# Altium schematic sheet C50-00020_Main.SchDoc(C50-00020_Main)
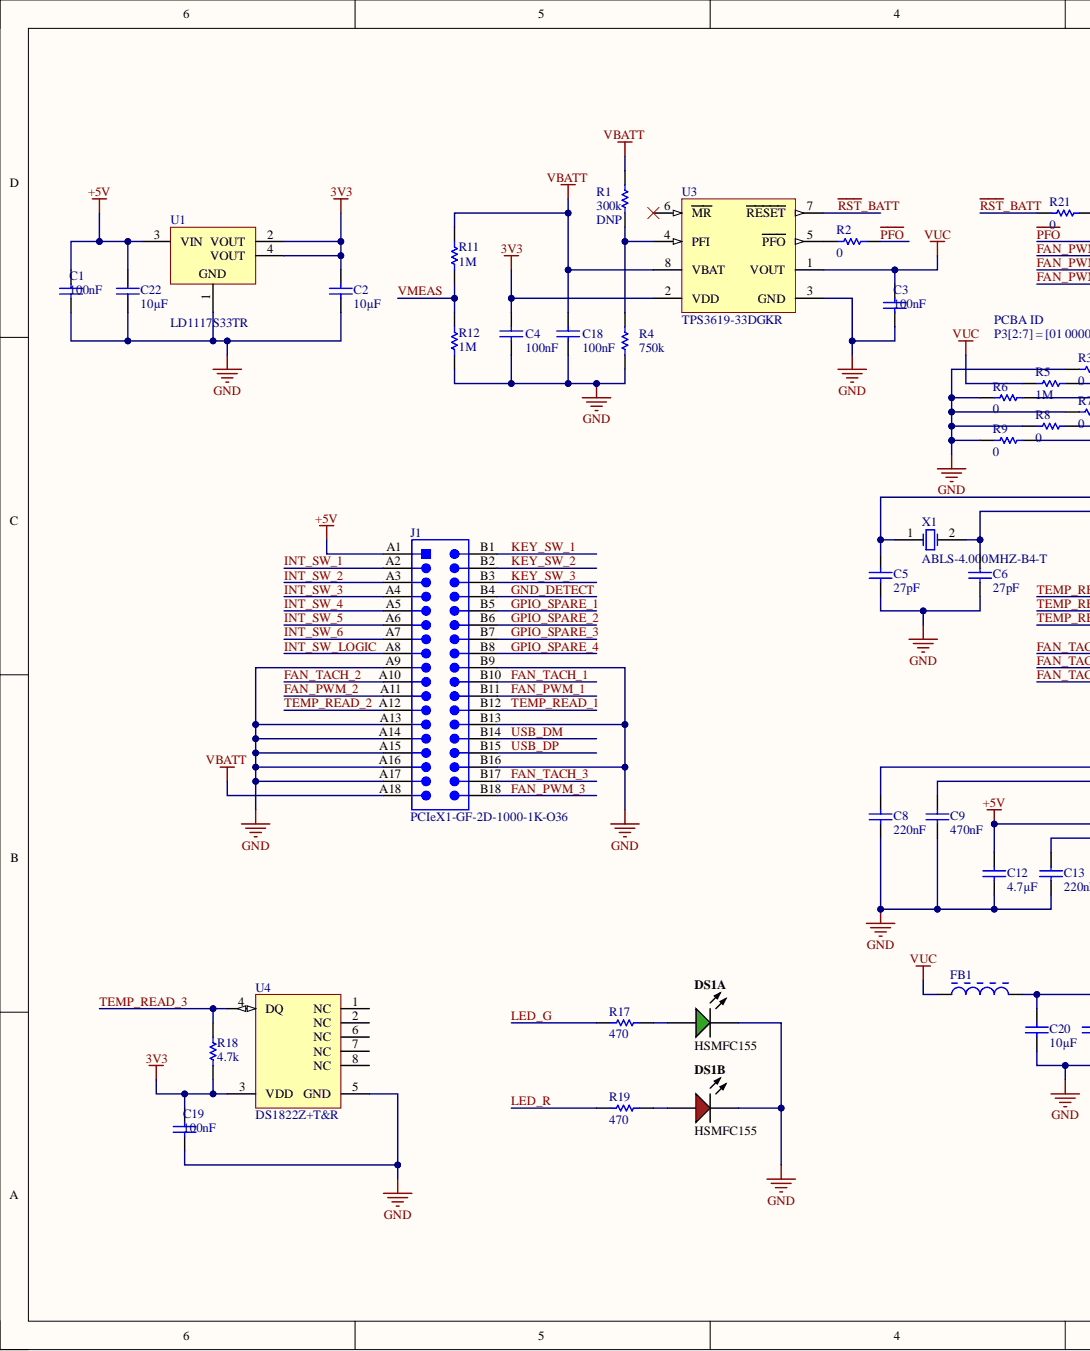
[diagram p0: C50-00020_Main.SchDoc, left]
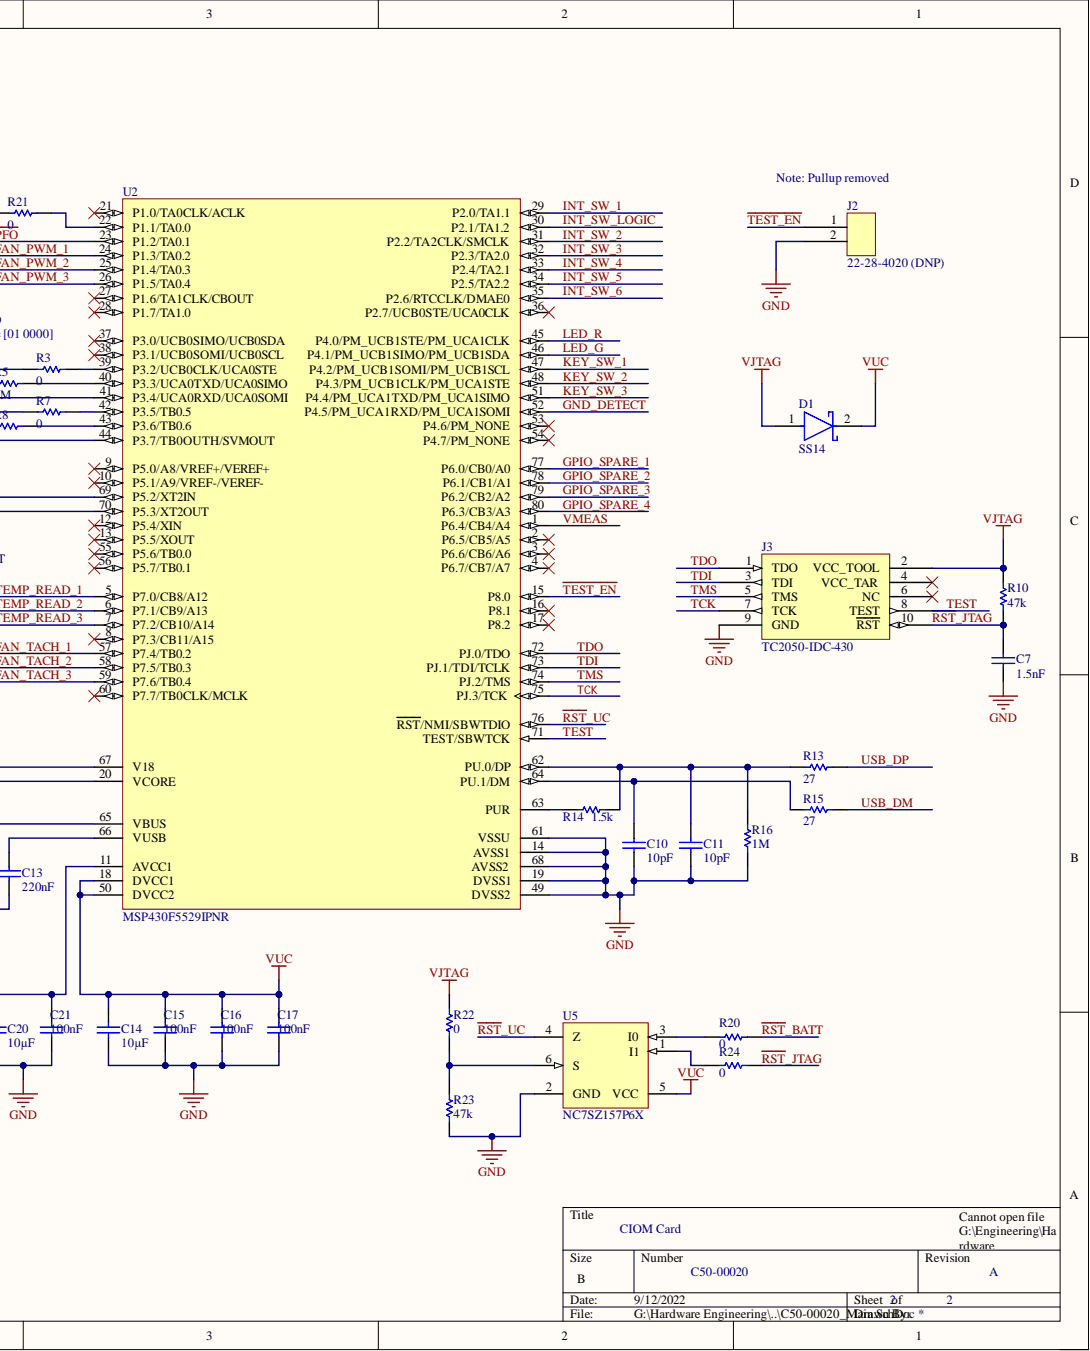
[diagram p1: C50-00020_Main.SchDoc, right]

## Parts
C1  value 100nF  [CAPC0402(1005)60_N]  (hidden comment: CL05A104KA5NNNC)
C2  value 10µF  [CAPC1608X100X20VL10T10]  (hidden comment: C1608X5R1E106M080AC)
C3  value 100nF  [CAPC0402(1005)60_N]  (hidden comment: CL05A104KA5NNNC)
C4  value 100nF  [CAPC0402(1005)60_N]  (hidden comment: CL05A104KA5NNNC)
C5  value 27pF  Capacitance: 27pF  [CAPC1005X56X25LL10T15]  (hidden comment: 04025A270JAT2A)
C6  value 27pF  Capacitance: 27pF  [CAPC1005X56X25LL10T15]  (hidden comment: 04025A270JAT2A)
C7  value 1.5nF  Capacitance: 1.5nF  [CAPC1005X56X25ML10T15]  (hidden comment: 04025C152KAT2A)
C8  value 220nF  Capacitance: 220nF  [CAPC1608X94X35ML15T15]  (hidden comment: 06033C224KAT2A)
C9  value 470nF  Capacitance: 470nF  [CAPC1608X90X35ML15T15]  (hidden comment: C0603C474K4PACTU)
C10  value 10pF  Capacitance: 10pF  [CAPC1005X55X25NL05T05]  (hidden comment: CC0402JRNPO9BN100)
C11  value 10pF  Capacitance: 10pF  [CAPC1005X55X25NL05T05]  (hidden comment: CC0402JRNPO9BN100)
C12  value 4.7µF  [CAPC1608X90X35NL10T25]  (hidden comment: EMK107ABJ475KA-T)
C13  value 220nF  Capacitance: 220nF  [CAPC1608X94X35ML15T15]  (hidden comment: 06033C224KAT2A)
C14  value 10µF  [CAPC1608X100X20VL10T10]  (hidden comment: C1608X5R1E106M080AC)
C15  value 100nF  [CAPC0402(1005)60_N]  (hidden comment: CL05A104KA5NNNC)
C16  value 100nF  [CAPC0402(1005)60_N]  (hidden comment: CL05A104KA5NNNC)
C17  value 100nF  [CAPC0402(1005)60_N]  (hidden comment: CL05A104KA5NNNC)
C18  value 100nF  [CAPC0402(1005)60_N]  (hidden comment: CL05A104KA5NNNC)
C19  value 100nF  [CAPC0402(1005)60_N]  (hidden comment: CL05A104KA5NNNC)
C20  value 10µF  [CAPC1608X100X20VL10T10]  (hidden comment: C1608X5R1E106M080AC)
C21  value 100nF  [CAPC0402(1005)60_N]  (hidden comment: CL05A104KA5NNNC)
C22  value 10µF  [CAPC1608X100X20VL10T10]  (hidden comment: C1608X5R1E106M080AC)
D1  SS14  [FP-403AE-MFG]
DS1  HSMFC155  [AVAG-HSMF-C15X_V]  (parts A,B)
FB1  BLM15AG102SN1D  [MURA-BLM15-CHIP-2_V]  (value not drawn)
J1  PCIeX1-GF-2D-1000-1K-O36  [PCIeX1-GF-2D-1000-1K-O36]  (no BOM)
J2  22-28-4020 (DNP)  [22-28-4020-Footprint-1]  (no BOM)
J3  TC2050-IDC-430  [TC2050-IDC-430-Footprint-1]  (no BOM)
R1  DNP  value 300k  [RESC1609X50X30NL10T20]  (no BOM)
R2  value 0  [RESC1608X55X25LL10T15]  (hidden comment: RC0603FR-070RL)
R3  value 0  [RESC1608X55X25LL10T15]  (hidden comment: RC0603FR-070RL)
R4  value 750k  [RESC1609X50X30NL10T20]  (hidden comment: CRCW0603750KFKEA)
R5  value 1M  [RESC1608X55X25ML10T15]  (hidden comment: RC0603FR-071ML)
R6  value 0  [RESC1608X55X25LL10T15]  (hidden comment: RC0603FR-070RL)
R7  RC0603FR-070RL  [RESC1608X55X25LL10T15]  (value not drawn)
R8  value 0  [RESC1608X55X25LL10T15]  (hidden comment: RC0603FR-070RL)
R9  value 0  [RESC1608X55X25LL10T15]  (hidden comment: RC0603FR-070RL)
R10  value 47k  [RESC1005X40X25LL10T10]  (hidden comment: CRG0402F47K)
R11  value 1M  [RESC1608X55X25LL10T15]  (hidden comment: RC0603FR-071ML)
R12  value 1M  [RESC1608X55X25LL10T15]  (hidden comment: RC0603FR-071ML)
R13  value 27  [RESC1005X40X25NL05T05]  (hidden comment: CRCW040227R0FKED)
R14  value 1.5k  [RESC1005X40X25ML05T10]  (hidden comment: RC0402FR-071K5L)
R15  value 27  [RESC1005X40X25NL05T05]  (hidden comment: CRCW040227R0FKED)
R16  value 1M  [RESC1608X55X25LL10T15]  (hidden comment: RC0603FR-071ML)
R17  value 470  [RESC1608X55X25NL10T15]  (hidden comment: AC0603FR-07470RL)
R18  value 4.7k  [RESC1608X55X25ML10T15]  (hidden comment: RC0603FR-074K7L)
R19  value 470  [RESC1608X55X25NL10T15]  (hidden comment: AC0603FR-07470RL)
R20  value 0  [RESC1608X55X25LL10T15]  (hidden comment: RC0603FR-070RL)
R21  value 0  [RESC1608X55X25LL10T15]  (hidden comment: RC0603FR-070RL)
R22  value 0  [RESC1608X55X25LL10T15]  (hidden comment: RC0603FR-070RL)
R23  value 47k  [RESC1005X40X25LL10T10]  (hidden comment: CRG0402F47K)
R24  value 0  [RESC1608X55X25LL10T15]  (hidden comment: RC0603FR-070RL)
U1  LD1117S33TR  [SOT-223_L]
U2  MSP430F5529IPNR  [PN0080A_L]
U3  TPS3619-33DGKR  [DGK0008A_M]
U4  DS1822Z+T&R  [MAXM-S8+2_N]
U5  NC7SZ157P6X  [FAIR-SC70-6_V]
X1  ABLS-4.000MHZ-B4-T  [ABLS-4.000MHZ-B4-T-Footprint-1]

## Nets
3V3: C2.2 C4.2 C19.2 R18.1 U1.2(VOUT) U1.4(VOUT) U3.2(VDD) U4.3(VDD)
+5V: C1.2 C12.1 C22.2 J1.A1 U1.3(VIN) U2.65(VBUS)
GND: C1.1 C2.1 C3.1 C4.1 C5.1 C6.1 C7.1 C8.2 C9.2 C10.1 C11.1 C12.2 C13.1 C14.1 C15.1 C16.1 C17.1 C18.1 C19.1 C20.1 C21.1 C22.1 DS1.2 DS1.4 J1.A9 J1.A13 J1.A14 J1.A15 J1.A16 J1.A17 J1.B9 J1.B13 J1.B16 J2.2 J3.9(GND) R3.1 R4.1 R6.1 R7.1 R8.1 R9.1 R12.1 R16.1 R23.1 U1.1 U2.14(AVSS1) U2.19(DVSS1) U2.49(DVSS2) U2.61(VSSU) U2.68(AVSS2) U3.3(GND) U4.5(GND) U5.2(GND)
VBATT: C18.2 J1.A18 R1.2 R11.2 U3.8(VBAT)
VJTAG: D1.1 J3.2(VCC_TOOL) R10.2 R22.1
VUC: C3.2 C14.2 C15.2 C16.2 C17.2 D1.2 FB1.1 R5.2 U2.18(DVCC1) U2.50(DVCC2) U3.1(VOUT) U5.5(VCC)
FAN_PWM_1: J1.B11 U2.24(P1.3/TA0.2)
FAN_PWM_2: J1.A11 U2.25(P1.4/TA0.3)
FAN_PWM_3: J1.B18 U2.26(P1.5/TA0.4)
FAN_TACH_1: J1.B10 U2.57(P7.4/TB0.2)
FAN_TACH_2: J1.A10 U2.58(P7.5/TB0.3)
FAN_TACH_3: J1.B17 U2.59(P7.6/TB0.4)
GND_DETECT: J1.B4 U2.52(P4.5/PM_UCA1RXD/PM_UCA1SOMI)
GPIO_SPARE_1: J1.B5 U2.77(P6.0/CB0/A0)
GPIO_SPARE_2: J1.B6 U2.78(P6.1/CB1/A1)
GPIO_SPARE_3: J1.B7 U2.79(P6.2/CB2/A2)
GPIO_SPARE_4: J1.B8 U2.80(P6.3/CB3/A3)
INT_SW_1: J1.A2 U2.29(P2.0/TA1.1)
INT_SW_2: J1.A3 U2.31(P2.2/TA2CLK/SMCLK)
INT_SW_3: J1.A4 U2.32(P2.3/TA2.0)
INT_SW_4: J1.A5 U2.33(P2.4/TA2.1)
INT_SW_5: J1.A6 U2.34(P2.5/TA2.2)
INT_SW_6: J1.A7 U2.35(P2.6/RTCCLK/DMAE0)
INT_SW_LOGIC: J1.A8 U2.30(P2.1/TA1.2)
KEY_SW_1: J1.B1 U2.47(P4.2/PM_UCB1SOMI/PM_UCB1SCL)
KEY_SW_2: J1.B2 U2.48(P4.3/PM_UCB1CLK/PM_UCA1STE)
KEY_SW_3: J1.B3 U2.51(P4.4/PM_UCA1TXD/PM_UCA1SIMO)
LED_G: R17.1 U2.46(P4.1/PM_UCB1SIMO/PM_UCB1SDA)
LED_R: R19.1 U2.45(P4.0/PM_UCB1STE/PM_UCA1CLK)
~{PFO}: R2.1 U2.23(P1.2/TA0.1)
~{RST}_BATT: R20.1 R21.2 U3.7(RESET)
~{RST}_JTAG: C7.2 J3.10(RST) R10.1 R24.1
~{RST}_UC: U2.76(RST/NMI/SBWTDIO) U5.4(Z)
~{TEST_EN}: J2.1 U2.15(P8.0)
TCK: J3.7(TCK) U2.75(PJ.3/TCK)
TDI: J3.3(TDI) U2.73(PJ.1/TDI/TCLK)
TDO: J3.1(TDO) U2.72(PJ.0/TDO)
TEMP_READ_1: J1.B12 U2.5(P7.0/CB8/A12)
TEMP_READ_2: J1.A12 U2.6(P7.1/CB9/A13)
TEMP_READ_3: R18.2 U2.7(P7.2/CB10/A14) U4.4(DQ)
TEST: J3.8(TEST) U2.71(TEST/SBWTCK)
TMS: J3.5(TMS) U2.74(PJ.2/TMS)
USB_DM: J1.B14 R15.2
USB_DP: J1.B15 R13.2
VMEAS: R11.1 R12.2 U2.1(P6.4/CB4/A4)
NetC5_2: C5.2 U2.69(P5.2/XT2IN) X1.1
NetC6_2: C6.2 U2.70(P5.3/XT2OUT) X1.2
NetC8_1: C8.1 U2.67(V18)
NetC9_1: C9.1 U2.20(VCORE)
NetC10_2: C10.2 R15.1 U2.64(PU.1/DM)
NetC11_2: C11.2 R13.1 R14.2 R16.2 U2.62(PU.0/DP)
NetC13_2: C13.2 U2.66(VUSB)
NetC20_2: C20.2 C21.2 FB1.2 U2.11(AVCC1)
NetDS1_1: DS1.1 R17.2
NetDS1_3: DS1.3 R19.2
NetR1_1: R1.1 R4.2 U3.4(PFI)
NetR2_2: R2.2 U3.5(PFO)
NetR3_2: R3.2 U2.39(P3.2/UCB0CLK/UCA0STE)
NetR5_1: R5.1 U2.40(P3.3/UCA0TXD/UCA0SIMO)
NetR6_2: R6.2 U2.41(P3.4/UCA0RXD/UCA0SOMI)
NetR7_2: R7.2 U2.42(P3.5/TB0.5)
NetR8_2: R8.2 U2.43(P3.6/TB0.6)
NetR9_2: R9.2 U2.44(P3.7/TB0OUTH/SVMOUT)
NetR14_1: R14.1 U2.63(PUR)
NetR20_2: R20.2 U5.3(I0)
NetR21_1: R21.1 U2.22(P1.1/TA0.0)
NetR22_2: R22.2 R23.2 U5.6(S)
NetR24_2: R24.2 U5.1(I1)

Not connected: J3 4(VCC_TAR) 6(NC); U2 2(P6.5/CB5/A5) 3(P6.6/CB6/A6) 4(P6.7/CB7/A7) 8(P7.3/CB11/A15) 9(P5.0/A8/VREF+/VEREF+) 10(P5.1/A9/VREF-/VEREF-) 12(P5.4/XIN) 13(P5.5/XOUT) 16(P8.1) 17(P8.2) 21(P1.0/TA0CLK/ACLK) 27(P1.6/TA1CLK/CBOUT) 28(P1.7/TA1.0) 36(P2.7/UCB0STE/UCA0CLK) 37(P3.0/UCB0SIMO/UCB0SDA) 38(P3.1/UCB0SOMI/UCB0SCL) 53(P4.6/PM_NONE) 54(P4.7/PM_NONE) 55(P5.6/TB0.0) 56(P5.7/TB0.1) 60(P7.7/TB0CLK/MCLK); U3 6(MR); U4 1(NC) 2(NC) 6(NC) 7(NC) 8(NC)

## Library data of the parts
C1 C3 C4 C15-C19 C21 = C51-C0064-5: CAP, Ceramic, 0.1uF, 0402, 25V, 10%, X5R, SMD; Tolerance: 20%; Case/Package: 0402; Component Kind: Standard; Max Operating Temperature: 125°C; Min Operating Temperature: -55°C; Voltage Rating: 25V; Library Name: AGS, LLC
C2 C14 C20 C22 = C51-C0063-5: CAP, Ceramic, 10uF, 0603, 25V, 20%, X5R, SMD; Capacitance: 10uF; Case Code (Imperial): 0603; Case Code (Metric): 1608; Case/Package: 0603; Component Kind: Standard; Composition: Ceramic; Depth: 0.8mm; Dielectric: X5R; Dielectric Material: Ceramic; Height: 0.8mm; Lead Free: Lead Free; Length: 1.6mm; Max Operating Temperature: 85°C; Min Operating Temperature: -55°C; Mount: Surface Mount; Package Quantity: 1; Packaging: Tape and Reel; REACH SVHC: No SVHC; Termination: SMD/SMT; Thickness: 0.039inch; Tolerance: 20%; Voltage Rating: 25V; Voltage Rating (DC): 25V; Weight: 7.1E-05oz; Width: 0.8mm; Library Name: AGS, LLC
C5 C6 = C51-C0028-3: CAP, Ceramic, 27pF, 0402, 50V, 5%, C0G/NP0, SMD; Dielectric: C0G; Temperature Coefficient: 0ppm/°C; Case Code (Imperial): 0402; Case Code (Metric): 1005; Case/Package: 0402; Component Kind: Standard; Contact Plating: Tin,Matte; Depth: 0.5mm; Dielectric Material: Ceramic; Height: 0.5mm; Lead Free: Lead Free; Length: 1mm; Max Operating Temperature: 125°C; Min Operating Temperature: -55°C; Mount: Surface Mount,PCB; Number of Pins: 2; Package Quantity: 1; Packaging: Tape and Reel; Radiation Hardening: No; REACH SVHC: No SVHC; Termination: SMD/SMT; Thickness: 0.022inch; Tolerance: 5%; Voltage: 50V; Voltage Rating: 50V; Voltage Rating (DC): 50V; Width: 0.02inch; Library Name: AGS, LLC
C7 = C51-C0027-4: CAP, Ceramic, 1500pF, 0402, 50V, 10%, X7R, SMD; Case Code (Imperial): 0402; Case Code (Metric): 1005; Case/Package: 0402; Component Kind: Standard; Contact Plating: Tin,Matte; Depth: 0.5mm; Dielectric: X7R; Dielectric Material: Ceramic; Height: 0.5mm; Lead Free: Lead Free; Length: 1mm; Max Operating Temperature: 125°C; Min Operating Temperature: -55°C; Mount: Surface Mount,PCB; Number of Pins: 2; Package Quantity: 1; Packaging: Tape and Reel; Radiation Hardening: No; REACH SVHC: No SVHC; Termination: SMD/SMT; Thickness: 0.022inch; Tolerance: 10%; Voltage: 50V; Voltage Rating: 50V; Voltage Rating (DC): 50V; Width: 0.02inch; Library Name: AGS, LLC
C8 C13 = C51-C0025-3: CAP, Ceramic, 0.22UF, 0603, 25V, 10%, X7R, SMD; Number of Capacitors: 4; Case Code (Imperial): 0603; Case Code (Metric): 1608; Case/Package: 0603; Component Kind: Standard; Contact Plating: Tin,Matte; Depth: 0.81mm; Dielectric: X7R; Height: 0.94mm; Lead Free: Lead Free; Length: 1.6mm; Max Operating Temperature: 125°C; Min Operating Temperature: -55°C; Mount: Surface Mount; Number of Pins: 2; Package Quantity: 4000; Packaging: Tape and Reel; Radiation Hardening: No; REACH SVHC: No SVHC; Terminal Pitch: 0.76mm; Termination: SMD/SMT; Tolerance: 10%; Voltage: 25V; Voltage Rating: 25V; Voltage Rating (DC): 25V; Width: 0.81mm; Library Name: AGS, LLC
C9 = C51-C0021-3: CAP, Ceramic, 0.47uF, 0603, 16V, 10%, X5R, SMD; Dissipation Factor: 5%; Case Code (Imperial): 0603; Case Code (Metric): 1608; Case/Package: 0603; Component Kind: Standard; Depth: 0.8mm; Dielectric: X5R; Dielectric Material: Ceramic; Height: 0.8mm; Lead Free: Lead Free; Lead Pitch: 0.7mm; Length: 1.6mm; Max Operating Temperature: 85°C; Min Operating Temperature: -55°C; Mount: Surface Mount; Package Quantity: 1; Packaging: Tape and Reel; Radiation Hardening: No; REACH SVHC: No SVHC; Termination: SMD/SMT; Thickness: 0.034inch; Tolerance: 10%; Voltage Rating: 16V; Voltage Rating (DC): 16V; Width: 0.031inch; Library Name: AGS, LLC
C10 C11 = C51-C0026-2: CAP, Ceramic, 10pF, 0402, 50V, 5%, C0G/NPO, SMD; Dielectric: C0G; Insulation Resistance: 100GR; Temperature Coefficient: 30ppm/°C; Case Code (Imperial): 0402; Case Code (Metric): 1005; Case/Package: 0402; Component Kind: Standard; Composition: Ceramic; Depth: 0.5mm; Dielectric Material: Ceramic; Height: 0.5mm; Lead Free: Lead Free; Length: 1mm; Max Operating Temperature: 125°C; Min Operating Temperature: -55°C; Mount: Surface Mount; Number of Pins: 2; Package Quantity: 1; Packaging: Tape and Reel; Radiation Hardening: No; REACH SVHC: No SVHC; Termination: SMD/SMT; Thickness: 0.022inch; Tolerance: 5%; Voltage: 50V; Voltage Rating: 50V; Voltage Rating (DC): 50V; Width: 0.02inch; Library Name: AGS, LLC
C12 = C51-C0024-3: CAP, Ceramic, 4.7uF, 0603, 10%, 25V, X5R, SMD; Case/Package: 0603; Component Kind: Standard; Max Operating Temperature: 85°C; Min Operating Temperature: -55°C; Tolerance: 10%; Voltage Rating: 25V; Library Name: AGS, LLC
D1 = C51-D0004-3: DIODE, Schottky, 40V, 1A, DO-214AC, SMD; Element Configuration: Single; Forward Voltage: 500mV; Max Forward Surge Current (Ifsm): 40A; Max Power Dissipation: 1.1W; Number of Elements: 1; Peak Reverse Current: 200uA; Polarity: Standard; Average Rectified Current: 1A; Case/Package: SMA; Component Kind: Standard; Current: 1A; Current Rating: 1A; Forward Current: 1A; Height: 2.2mm; Lead Free: Lead Free; Length: 4.75mm; Max Operating Temperature: 125°C; Max Repetitive Reverse Voltage (Vrrm): 40V; Max Reverse Voltage (DC): 40V; Min Operating Temperature: -65°C; Mount: Surface Mount; Number of Pins: 2; Number of Terminals: 2; Output Current: 1A; Package Quantity: 7500; Packaging: Tape and Reel; Peak Non-Repetitive Surge Current: 40A; Power Dissipation: 1.1W; Radiation Hardening: No; REACH SVHC: No SVHC; Termination: SMD/SMT; Voltage: 40V; Voltage Rating (DC): 40V; Weight: 0.003739oz; Width: 2.95mm; Library Name: AGS, LLC
DS1 = C51-D0003-2: LED, Red/Green, 10/15mcd, Diffused, 1210, SMD; Color: Green,Red; Dominant Wavelength: 626nm; Forward Current: 20mA; Forward Voltage: 2.2V; Lens Color: White; Lens Style: Diffused,Colorless,Rectangular; Luminous Intensity: 10mcd; Max Power Dissipation: 65mW; Number of Elements: 2; Reverse Voltage: 5V; Viewing Angle: 170°; Voltage Rating (DC): 1.1V; Wavelength: 572nm; Case Code (Imperial): 1210; Case Code (Metric): 3225; Case/Package: 1210; Component Kind: Standard; Contact Plating: Gold; Depth: 3.2mm; Height: 1.1mm; Illumination Color: Green,Red; Lead Free: Lead Free; Length: 2.7mm; Lens Transparency: Diffused; Max Operating Temperature: 85°C; Min Operating Temperature: -40°C; Mount: Surface Mount; Number of LEDs: 2; Number of Pins: 4; Package Quantity: 1; Packaging: Tape and Reel; Power Dissipation: 65mW; Power Rating: 65mW; Radiation Hardening: No; REACH SVHC: No SVHC; Test Current: 20mA; Width: 2.7mm; Library Name: AGS, LLC
FB1 = C51-L0003-3: IND, Ferrite Bead, 1kOhm, 100MHz, 300mA, 0402, SMD; DC Current: 200mA; DC Resistance (DCR): 650mR; Impedance: 1kR; Material: Ferrite,Steel; Number of Circuits: 1; Shielding: Unshielded; Tolerance: 25%; Case Code (Imperial): 0402; Case Code (Metric): 1005; Case/Package: 0402; Component Kind: Standard; Core Material: Ferrite; Current Rating: 300mA; Depth: 0.55mm; Frequency: 100MHz; Height: 0.5mm; Lead Free: Lead Free; Length: 1mm; Max Current Rating: 300mA; Max DC Current: 200mA; Max Operating Temperature: 125°C; Military Standard: Not; Min Operating Temperature: -55°C; Number of Lines: 1; Number of Pins: 2; Package Quantity: 1; Packaging: Tape and Reel; Radiation Hardening: No; REACH SVHC: No SVHC; Termination: SMD/SMT; Test Frequency: 100MHz; Width: 0.5mm; Library Name: AGS, LLC
J1 = C51-J0016-1: Golden Finger, PCIeX1, Dual, Opposite, Pitch 1mm, 36-Position; Connector Type: EdgeConnector; Gender: Male; Case/Package: PCIeX1-GF-2D-1000-1K-O36; Library Name: <Company> Server; Mounting Technology: SM; Package Description: Edge Connector, Golden Finger, Pitch 1 mm, 2 x 18 Position; Package Reference: PCIeX1-GF-2D-1000-1K-O36; Pins: 36
J2 = C51-J0018-5: CONN, Header, KK 254, Unshrouded, 2Pos, Single Row, Vert, TH, Tin; Connector Type: Male Pin; Contact Resistance: 20mR; Current Rating: 4A; Gender: Male; Glow Wire Compliant: Non-compliant; Max Voltage Rating (DC): 250.25kV; Number of Circuits: 2; Pitch: 2.54mm; Voltage Rating: 250V; Color: Black; Contact Material: Brass,Bronze; Contact Mating Length: 0.24inch; Contact Plating: Tin; Flammability Rating: UL94 V-0; Housing Color: Black; Housing Material: Thermoplastic; Lead Free: Lead Free; Max Operating Temperature: 75°C; Min Operating Temperature: 0°C; Mount: Through Hole; Number of Contacts: 2; Number of Positions: 2; Number of Rows: 1; Orientation: Straight; Package Quantity: 1; Packaging: Bulk; Radiation Hardening: No; REACH SVHC: Unknown; Termination: Solder; Voltage Rating (DC): 250V; Library Name: AGS, LLC
J3 = C51-J0017-8: CONN, Plug-of-Nails, Tag-Connect, TC2050-IDC-430 Cable, No BOM; Package Quantity: 1; Library Name: AGS, LLC
R1 = C51-R0156-2: RES, 300k, 0603, 1%, 1/10W, 75V, SMD; Number of Terminals/Pins: 2; Package Height: 0.45mm; Package Length: 1.55mm; Package Width: 0.85mm; Rated Power Dissipation (P): 100mW; Rated Temperature: 70°C; Reference Standard: AEC-Q200; Resistance: 300kR; Temperature Coefficient: 100ppm/°C; Construction: Chip; JESD-609 Code: e3; Max Operating Temperature: 155°C; Min Operating Temperature: -55°C; Mount: Surface Mount; Package Shape: Rectangular; Package Style: SMTMeter; Packaging: Tape and Reel; REACH SVHC: Yes; Resistor Type: FIXED RESISTOR; RoHS Compliant: Yes; Size Code: 0603; Technology: METAL GLAZE/THICK FILM; Terminal Finish: Nickel; Termination: Wraparound; Tolerance: 1%; Working Voltage: 75V; Library Name: AGS, LLC
R2 R3 R6 R8 R9 R20-R22 R24 = C51-R0035-3: RES, 0, 0603, 1/10W, SMD; Current Rating: 1A; Max Power Dissipation: 100mW; Resistance: 0mR; Temperature Coefficient: 200ppm/°C; Tolerance: 1%; Voltage Rating: 50V; Working Voltage: 75V; Case Code (Imperial): 0603; Case Code (Metric): 1608; Case/Package: 0603; Component Kind: Standard; Composition: Thick Film; Contact Plating: Tin,Matte; Depth: 0.8mm; Features: Moisture Resistant; Height: 0.45mm; Lead Free: Lead Free; Length: 1.6mm; Max Operating Temperature: 155°C; Military Standard: Not; Min Operating Temperature: -55°C; Number of Pins: 2; Number of Terminations: 2; Package Quantity: 5000; Packaging: Tape and Reel; Power Rating: 100mW; Radiation Hardening: No; REACH SVHC: No SVHC; Termination: SMD/SMT; Voltage Rating (DC): 50V; Width: 0.031inch; Library Name: AGS, LLC
R4 = C51-R0157-2: RES, 750k, 0603, 1%, 1/10W, 75V, SMD; Number of Terminals/Pins: 2; Package Height: 0.45mm; Package Length: 1.55mm; Package Width: 0.85mm; Rated Power Dissipation (P): 100mW; Rated Temperature: 70°C; Reference Standard: AEC-Q200; Resistance: 750kR; Temperature Coefficient: 100ppm/°C; Component Kind: Standard; Construction: Chip; JESD-609 Code: e3; Max Operating Temperature: 155°C; Min Operating Temperature: -55°C; Mount: Surface Mount; Package Shape: Rectangular; Package Style: SMTMeter; Packaging: Tape and Reel; REACH SVHC: Yes; Resistor Type: FIXED RESISTOR; RoHS Compliant: Yes; Size Code: 0603; Technology: METAL GLAZE/THICK FILM; Terminal Finish: Nickel; Termination: Wraparound; Tolerance: 1%; Working Voltage: 75V; Library Name: AGS, LLC
R5 R11 R12 R16 = C51-R0037-2: RES, 1M, 0603, 1%, 1/10W, SMD; Power Rating: 100mW; Resistance: 1MR; Temperature Coefficient: 100ppm/°C; Voltage Rating: 50V; Case Code (Imperial): 0603; Case Code (Metric): 1608; Case/Package: 0603; Component Kind: Standard; Composition: Thick Film; Depth: 0.8mm; Height: 0.45mm; Lead Free: Lead Free; Length: 1.6mm; Max Operating Temperature: 155°C; Military Standard: Not; Min Operating Temperature: -55°C; Number of Pins: 2; Package Quantity: 5000; Packaging: Tape and Reel; REACH SVHC: No SVHC; Termination: SMD/SMT; Tolerance: 1%; Voltage Rating (DC): 50V; Width: 0.8mm; Library Name: AGS, LLC
R7 = C51-R0035-3: RES, 0, 0603, 1/10W, SMD; Current Rating: 1A; Max Power Dissipation: 100mW; Resistance: 0mR; Temperature Coefficient: 200ppm/°C; Tolerance: 1%; Value: 0; Voltage Rating: 50V; Working Voltage: 75V; Case Code (Imperial): 0603; Case Code (Metric): 1608; Case/Package: 0603; Component Kind: Standard; Composition: Thick Film; Contact Plating: Tin,Matte; Depth: 0.8mm; Features: Moisture Resistant; Height: 0.45mm; Lead Free: Lead Free; Length: 1.6mm; Max Operating Temperature: 155°C; Military Standard: Not; Min Operating Temperature: -55°C; Number of Pins: 2; Number of Terminations: 2; Package Quantity: 5000; Packaging: Tape and Reel; Power Rating: 100mW; Radiation Hardening: No; REACH SVHC: No SVHC; Termination: SMD/SMT; Voltage Rating (DC): 50V; Width: 0.031inch; Library Name: AGS, LLC
R10 R23 = C51-R0041-3: RES, 47k, 0402, 1%, 1/16W, SMD; Number of Resistors: 1; Power Rating: 63mW; Resistance: 47kR; Temperature Coefficient: 100ppm/°C; Voltage Rating: 50V; Case Code (Imperial): 0402; Case Code (Metric): 1005; Case/Package: 0402; Component Kind: Standard; Composition: Thick Film; Depth: 0.5mm; ELV: Compliant; Height: 0.35mm; Length: 1mm; Max Operating Temperature: 125°C; Min Operating Temperature: -55°C; Mount: Surface Mount; Number of Terminations: 2; Packaging: Tape and Reel; Radiation Hardening: No; REACH SVHC: No SVHC; Termination: Solder; Tolerance: 1%; Voltage Rating (DC): 50V; Width: 0.5mm; Library Name: AGS, LLC
R13 R15 = C51-R0080-2: RES, 27, 0402, 1%, 1/16W, SMD; Max Power Dissipation: 63mW; Resistance: 27R; Temperature Coefficient: 100ppm/°C; Voltage Rating: 50V; Case Code (Imperial): 0402; Case Code (Metric): 1005; Case/Package: 0402; Component Kind: Standard; Composition: Thick Film; Contact Plating: Tin; Depth: 0.5mm; Features: Automotive AEC-Q200; Height: 0.35mm; Lead Free: Lead Free; Length: 1mm; Max Operating Temperature: 155°C; Military Standard: Not; Min Operating Temperature: -55°C; Number of Pins: 2; Number of Terminations: 2; Package Quantity: 10; Packaging: Tape and Reel; Power Rating: 63mW; Radiation Hardening: No; REACH SVHC: Unknown; Termination: SMD/SMT; Tolerance: 1%; Voltage Rating (DC): 50V; Weight: 2.3E-05oz; Width: 0.02inch; Library Name: AGS, LLC
R14 = C51-R0085-2: RES, 1.5k, 0402, 1%, 1/16W, SMD; Max Power Dissipation: 63mW; Power Rating: 62.5mW; Resistance: 1.5kR; Temperature Coefficient: 100ppm/°C; Voltage Rating: 50V; Case Code (Imperial): 0402; Case Code (Metric): 1005; Case/Package: 0402; Component Kind: Standard; Composition: Thick Film; Depth: 0.5mm; Features: Moisture Resistant; Height: 0.016inch; Lead Free: Lead Free; Length: 1mm; Max Operating Temperature: 155°C; Military Standard: Not; Min Operating Temperature: -55°C; Number of Terminations: 2; Package Quantity: 1; Packaging: Tape and Reel; REACH SVHC: No SVHC; Termination: SMD/SMT; Tolerance: 1%; Width: 0.02inch; Library Name: AGS, LLC
R17 R19 = C51-R0083-2: RES, 470, 0603, 1%, 1/10W, SMD; Max Power Dissipation: 100mW; Resistance: 470R; Temperature Coefficient: 100ppm/°C; Voltage Rating: 50V; Case Code (Imperial): 0603; Case Code (Metric): 1608; Case/Package: 0603; Component Kind: Standard; Composition: Thick Film; Features: Moisture Resistant,Automotive AEC-Q200,Anti-Sulfur; Height: 0.022inch; Lead Free: Lead Free; Length: 0.063inch; Max Operating Temperature: 155°C; Min Operating Temperature: -55°C; Number of Terminations: 2; Package Quantity: 1; Packaging: Tape and Reel; Power Rating: 100mW; Termination: SMD/SMT; Tolerance: 1%; Width: 0.031inch; Library Name: AGS, LLC
R18 = C51-R0038-2: RES, 4.7k, 0603, 1%, 1/10W, SMD; Power Rating: 100mW; Resistance: 4.7kR; Temperature Coefficient: 100ppm/°C; Voltage Rating: 50V; Case Code (Imperial): 0603; Case Code (Metric): 1608; Case/Package: 0603; Component Kind: Standard; Composition: Thick Film; Depth: 0.8mm; Height: 0.45mm; Lead Free: Lead Free; Lead Pitch: 0.3mm; Length: 1.6mm; Max Operating Temperature: 155°C; Military Standard: Not; Min Operating Temperature: -55°C; Number of Pins: 2; Packaging: Tape and Reel; REACH SVHC: No SVHC; Termination: SMD/SMT; Tolerance: 1%; Voltage Rating (DC): 50V; Width: 0.8mm; Library Name: AGS, LLC
U1 = C51-U0013-3: IC, LDO, 3.3V, 800mA, LD1117, SOT-223; Accuracy: 1%; Dropout Voltage: 1.1V; Input Bias Current: 5mA; Max Input Voltage: 15V; Max Output Current: 1.3A; Max Power Dissipation: 12W; Min Input Voltage: 4.75V; Number of Outputs: 1; Output Type: Fixed; Polarity: Positive; Quiescent Current: 10mA; Case/Package: SOT-223; Component Kind: Standard; Contact Plating: Tin,Matte; Height: 1.8mm; Lead Free: Lead Free; Length: 6.5mm; Max Operating Temperature: 125°C; Max Output Voltage: 3.3V; Max Supply Voltage: 15V; Min Operating Temperature: 0°C; Min Output Voltage: 3.3V; Min Supply Voltage: 4.75V; Mount: Surface Mount; Nominal Output Voltage: 3.3V; Number of Pins: 4; Number of Regulators: 1; Output Current: 800mA; Output Voltage: 3.3V; Output Voltage Accuracy: 1%; Package Quantity: 1; Packaging: Tape and Reel; Power Dissipation: 12W; Radiation Hardening: No; REACH SVHC: No SVHC; Termination: SMD/SMT; Voltage: 3.3V; Weight: 0.01lb; Width: 3.5mm; Library Name: AGS, LLC
U2 = C51-U0011-2: IC, MCU, 16bit, 128kB Flash, MSP430, 80LQFP; Data Bus Width: 16b; Frequency: 25MHz; Interface: I2C,SPI,USB,IrDA,UART,USART,LIN,SCI; Max Supply Voltage: 3.6V; Min Supply Voltage: 1.8V; Number of A/D Converters: 16; Number of GPIO: 63; Number of Timers/Counters: 4; Number of UART Channels: 2; Oscillator Type: Internal; Peripherals: Brown-out Detect/Reset,POR,PWM,WDT,DMA; RAM Size: 10KB; Watchdog Timer: Yes; Case/Package: LQFP; Component Kind: Standard; Contact Plating: Gold; Core Architecture: MSP430; Lead Free: Lead Free; Max Frequency: 25MHz; Max Operating Temperature: 85°C; Memory Size: 128KB; Memory Type: FLASH; Min Operating Temperature: -40°C; Number of ADC Channels: 16; Number of I/Os: 63; Number of Pins: 80; Number of Programmable I/O: 63; Package Quantity: 1000; Packaging: Tape and Reel; Radiation Hardening: No; REACH SVHC: No SVHC; Library Name: AGS, LLC
U3 = C51-U0012-3: IC, Supervisor, Push-Pull, 1-Channel, TPS3619, 8VSSOP; Max Power Dissipation: 470mW; Max Reset Threshold Voltage: 3V; Max Supply Current: 40uA; Max Supply Voltage: 5.5V; Min Reset Threshold Voltage: 2.88V; Min Supply Voltage: 1.65V; Number of Elements: 1; Operating Supply Current: 15uA; Operating Supply Voltage: 3.3V; Reset: Active Low; Reset Timeout: 60ms; Threshold Voltage: 2.93V; Watchdog Timer: No; Case/Package: VSSOP; Component Kind: Standard; Lead Free: Lead Free; Max Operating Temperature: 85°C; Min Operating Temperature: -40°C; Nominal Supply Current: 40uA; Number of Pins: 8; Number of Voltages Monitored: 1; Overvoltage Threshold: 3V; Package Quantity: 2500; Packaging: Tape and Reel; Power Dissipation: 470mW; Quiescent Current: 15uA; Radiation Hardening: No; Undervoltage Threshold: 2.93V; Library Name: AGS, LLC
U4 = C51-U0014-2: IC, Sensor, Temperature, Serial (1-Wire), DS1822, 8-SOIC; Max Supply Voltage (DC): 5.5V; Min Supply Voltage (DC): 3V; Operating Supply Current: 1mA; Output Type: Digital; Resolution: 11b; Case/Package: SOIC; Component Kind: Standard; Contact Plating: Tin,Matte; Interface: Serial; Lead Free: Lead Free; Max Operating Temperature: 125°C; Min Operating Temperature: -55°C; Number of Pins: 8; Operating Supply Voltage: 5.5V; Package Quantity: 2500; Packaging: Tape and Reel; Radiation Hardening: No; Library Name: AGS, LLC
U5 = C51-U0048-1: Multiplexer, LVC/LCX/Z Series, 1-Func, 2 Line Input, 1 Line Output, True Output, CMOS, PDSO6; Component Kind: Standard; Max Operating Temperature: 85°C; Min Operating Temperature: -40°C; RoHS Compliant: Yes; Library Name: AGS, LLC
X1 = C51-X0001-4: XTAL, 4MHz, 18pF, SMD; ESR (Equivalent Series Resistance): 180R; Frequency Stability: 50ppm; Frequency Tolerance: 30ppm; Case/Package: SMD/SMT; Component Kind: Standard; Depth: 4.7mm; Frequency: 4MHz; Height: 0.165inch; Lead Free: Lead Free; Length: 11.4mm; Load Capacitance: 18pF; Max Operating Temperature: 70°C; Min Operating Temperature: -20°C; Mount: Surface Mount; Package Quantity: 1000; Packaging: Tape and Reel; Radiation Hardening: No; REACH SVHC: Yes; Series Resistance: 180R; Termination: SMD/SMT; Tolerance: 30ppm; Width: 0.185inch; Library Name: AGS, LLC
